# Altium schematic sheet FEZ-Feather-Micro.SchDoc(FEZ-Feather-Micro)
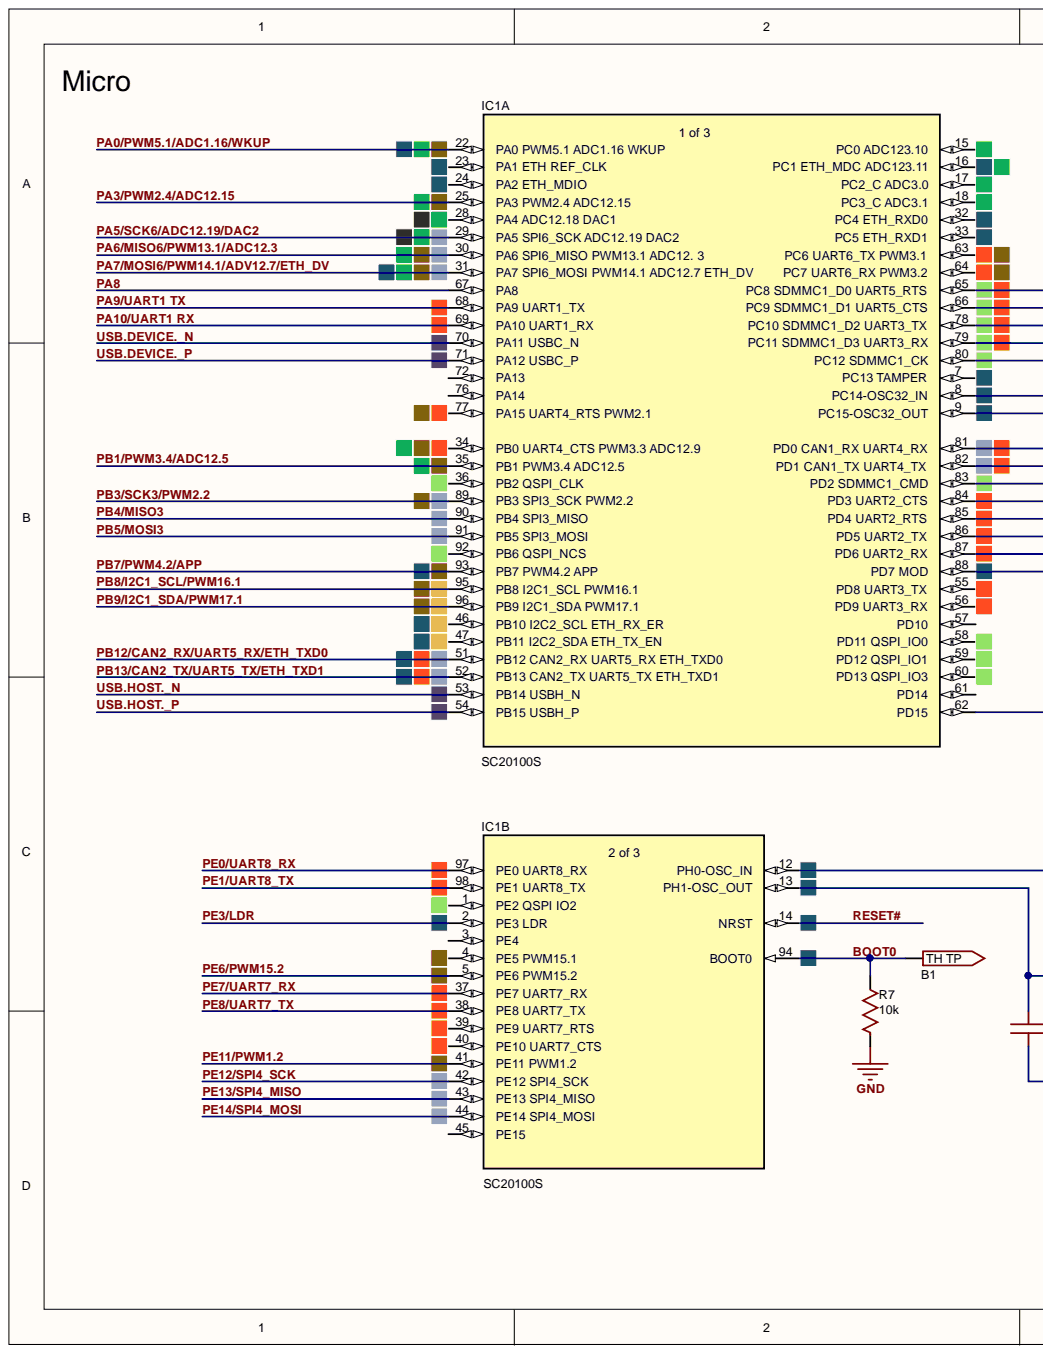
[diagram p0: FEZ-Feather-Micro.SchDoc, left]
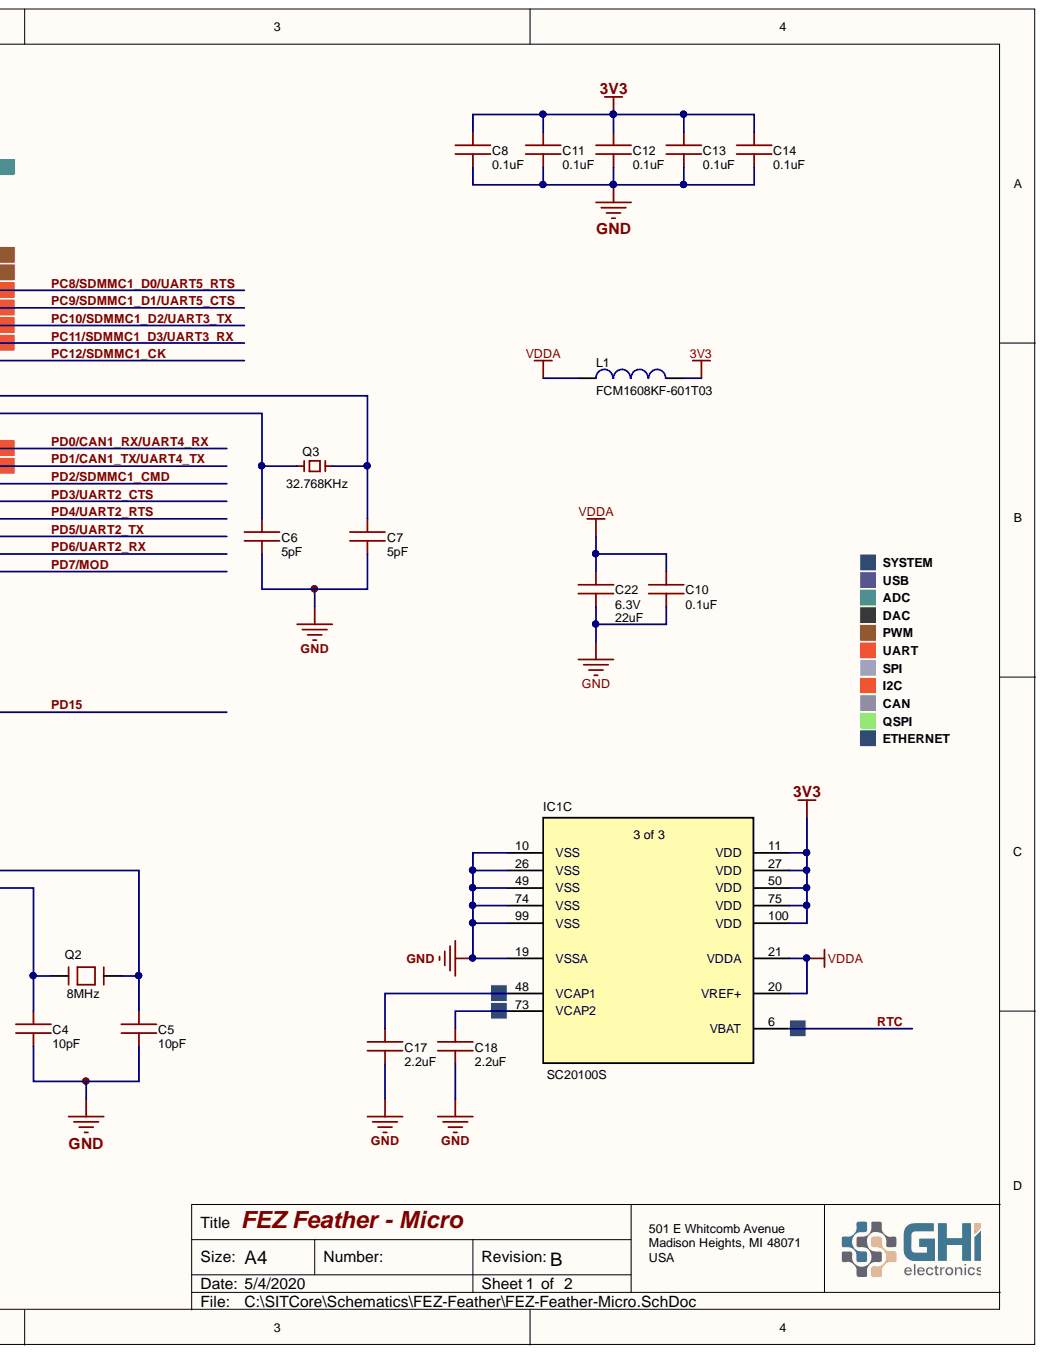
[diagram p1: FEZ-Feather-Micro.SchDoc, right]

## Parts
B1  TH Testpad 32mil (32mil ar)  [32mil TH]  (value not drawn)  (no BOM)
C4  value 10pF  [C0402]  (hidden comment: 0402 Cap 10pF)
C5  value 10pF  [C0402]  (hidden comment: 0402 Cap 10pF)
C6  value 5pF  [C0402]  (hidden comment: 0402 Cap 5pF)
C7  value 5pF  [C0402]  (hidden comment: 0402 Cap 5pF)
C8  value 0.1uF  [C0402]  (hidden comment: 0402 Cap 0.1uF)
C10  value 0.1uF  [C0402]  (hidden comment: 0402 Cap 0.1uF)
C11  value 0.1uF  [C0402]  (hidden comment: 0402 Cap 0.1uF)
C12  value 0.1uF  [C0402]  (hidden comment: 0402 Cap 0.1uF)
C13  value 0.1uF  [C0402]  (hidden comment: 0402 Cap 0.1uF)
C14  value 0.1uF  [C0402]  (hidden comment: 0402 Cap 0.1uF)
C17  value 2.2uF  [C0603 (1608 Metric)]  (hidden comment: 0603 Cap 2.2uF)
C18  value 2.2uF  [C0603 (1608 Metric)]  (hidden comment: 0603 Cap 2.2uF)
C22  value 22uF  Voltage: 6.3V  [C0805 L]  (hidden comment: 0805 Cap 22uF)
IC1  SC20100S  [LQFP100]  (parts A,B,C)
IC1D  SC20100S  [LQFP100]  (no BOM)
L1  value FCM1608KF-601T03  [L0603]  (hidden comment: BEAD)
Q2  value 8MHz  [CRYSTAL_3.2X2.5]  (hidden comment: CRYSTAL3.2X2.5)
Q3  value 32.768KHz  [Crystal 3.2mm x 1.5mm]  (hidden comment: 32.768KHz 7pF 20ppm)
R7  value 10k  [R0603 (1608 Metric)]  (hidden comment: 0603 10K)

## Nets
3V3: C8.2 C11.2 C12.2 C13.2 C14.2 IC1.11(VDD) IC1.27(VDD) IC1.50(VDD) IC1.75(VDD) IC1.100(VDD) L1.2
GND: C4.1 C5.1 C6.1 C7.1 C8.1 C10.1 C11.1 C12.1 C13.1 C14.1 C17.1 C18.1 C22.1 IC1.10(VSS) IC1.19(VSSA) IC1.26(VSS) IC1.49(VSS) IC1.74(VSS) IC1.99(VSS) R7.1
VDDA: C10.2 C22.2 IC1.20(VREF+) IC1.21(VDDA) L1.1
BOOT0: B1.1 IC1.94(BOOT0) R7.2
PA0/PWM5.1/ADC1.16/WKUP: IC1.22(PA0_PWM5.1_ADC1.16_WKUP)
PA3/PWM2.4/ADC12.15: IC1.25(PA3_PWM2.4_ADC12.15)
PA5/SCK6/ADC12.19/DAC2: IC1.29(PA5_SPI6_SCK_ADC12.19_DAC2)
PA6/MISO6/PWM13.1/ADC12.3: IC1.30
PA7/MOSI6/PWM14.1/ADV12.7/ETH_DV: IC1.31
PA8: IC1.67(PA8)
PA9/UART1 TX: IC1.68(PA9_UART1_TX)
PA10/UART1 RX: IC1.69(PA10_UART1_RX)
PB1/PWM3.4/ADC12.5: IC1.35(PB1_PWM3.4_ADC12.5)
PB3/SCK3/PWM2.2: IC1.89(PB3_SPI3_SCK_PWM2.2)
PB4/MISO3: IC1.90(PB4_SPI3_MISO)
PB5/MOSI3: IC1.91(PB5_SPI3_MOSI)
PB7/PWM4.2/APP: IC1.93(PB7_PWM4.2_APP)
PB8/I2C1_SCL/PWM16.1: IC1.95(PB8_I2C1_SCL_PWM16.1)
PB9/I2C1_SDA/PWM17.1: IC1.96(PB9_I2C1_SDA_PWM17.1)
PB12/CAN2_RX/UART5_RX/ETH_TXD0: IC1.51(PB12_CAN2_RX_UART5_RX_ETH_TXD0)
PB13/CAN2_TX/UART5_TX/ETH_TXD1: IC1.52(PB13_CAN2_TX_UART5_TX_ETH_TXD1)
PC8/SDMMC1_D0/UART5_RTS: IC1.65(PC8_SDMMC1_D0_UART5_RTS)
PC9/SDMMC1_D1/UART5_CTS: IC1.66(PC9_SDMMC1_D1_UART5_CTS)
PC10/SDMMC1_D2/UART3_TX: IC1.78(PC10_SDMMC1_D2_UART3_TX)
PC11/SDMMC1_D3/UART3_RX: IC1.79(PC11_SDMMC1_D3_UART3_RX)
PC12/SDMMC1_CK: IC1.80(PC12_SDMMC1_CK)
PD0/CAN1_RX/UART4_RX: IC1.81(PD0_CAN1_RX_UART4_RX)
PD1/CAN1_TX/UART4_TX: IC1.82(PD1_CAN1_TX_UART4_TX)
PD2/SDMMC1_CMD: IC1.83(PD2_SDMMC1_CMD)
PD3/UART2_CTS: IC1.84(PD3_UART2_CTS)
PD4/UART2_RTS: IC1.85(PD4_UART2_RTS)
PD5/UART2_TX: IC1.86(PD5_UART2_TX)
PD6/UART2_RX: IC1.87(PD6_UART2_RX)
PD7/MOD: IC1.88(PD7_MOD)
PD15: IC1.62(PD15)
PE0/UART8_RX: IC1.97(PE0_UART8_RX)
PE1/UART8_TX: IC1.98(PE1_UART8_TX)
PE3/LDR: IC1.2(PE3_LDR)
PE6/PWM15.2: IC1.5(PE6_PWM15.2)
PE7/UART7_RX: IC1.37(PE7_UART7_RX)
PE8/UART7_TX: IC1.38(PE8_UART7_TX)
PE11/PWM1.2: IC1.41(PE11_PWM1.2)
PE12/SPI4_SCK: IC1.42(PE12_SPI4_SCK)
PE13/SPI4_MISO: IC1.43(PE13_SPI4_MISO)
PE14/SPI4_MOSI: IC1.44(PE14_SPI4_MOSI)
RESET#: IC1.14(NRST)
RTC: IC1.6(VBAT)
USB.DEVICE._N: IC1.70(PA11_USBC_N)
USB.DEVICE._P: IC1.71(PA12_USBC_P)
USB.HOST._N: IC1.53(PB14_USBH_N)
USB.HOST._P: IC1.54(PB15_USBH_P)
NetC4_2: C4.2 IC1.13(PH1-OSC_OUT) Q2.1
NetC5_2: C5.2 IC1.12(PH0-OSC_IN) Q2.3
NetC6_2: C6.2 IC1.9(PC15-OSC32_OUT) Q3.1
NetC7_2: C7.2 IC1.8(PC14-OSC32_IN) Q3.2
NetC17_2: C17.2 IC1.48(VCAP1)
NetC18_2: C18.2 IC1.73(VCAP2)

Not connected: IC1 1(PE2_QSPI_IO2) 3(PE4) 4(PE5_PWM15.1) 7(PC13_TAMPER) 15(PC0_ADC123.10) 16(PC1_ETH_MDC_ADC123.11) 17(PC2_C_ADC3.0) 18(PC3_C_ADC3.1) 23(PA1_ETH_REF_CLK) 24(PA2_ETH_MDIO) 28(PA4_ADC12.18_DAC1) 32(PC4_ETH_RXD0) 33(PC5_ETH_RXD1) 34(PB0_UART4_CTS_PWM3.3_ADC12.9) 36(PB2_QSPI_CLK) 39(PE9_UART7_RTS) 40(PE10_UART7_CTS) 45(PE15) 46(PB10_I2C2_SCL_ETH_RX_ER) 47(PB11_I2C2_SDA_ETH_TX_EN) 55(PD8_UART3_TX) 56(PD9_UART3_RX) 57(PD10) 58(PD11_QSPI_IO0) 59(PD12_QSPI_IO1) 60(PD13_QSPI_IO3) 61(PD14) 63(PC6_UART6_TX_PWM3.1) 64(PC7_UART6_RX_PWM3.2) 72(PA13) 76(PA14) 77(PA15_UART4_RTS_PWM2.1) 92(PB6_QSPI_NCS)

## Library data of the parts
B1 = TH Testpad 32mil (32mil ar): TH Testpad 32mil (32mil annular ring); Library Name: GHI.SchLib
C4 C5 = 0402 Cap 10pF: 10pF ±0.5pF 50V C0G 0402 Multilayer Ceramic Capacitors MLCC - SMD/SMT RoHS; Mfr.Part #: CL05C100DB5NNNC; Manufacturer: Samsung Electro-Mechanics; Voltage: 50V; Tolerance: ±0.5pF; Package: 0402; LCSC Part #: C307440; Component Kind: Standard; Category: Ceramic; Capacitance: 10pF; Library Name: GHI-Passives.SchLib
C6 C7 = 0402 Cap 5pF: 5pF ±0.1pF 50V C0G 0402 Multilayer Ceramic Capacitors MLCC - SMD/SMT RoHS; Mfr.Part #: CL05C050BB5NNNC; Manufacturer: Samsung Electro-Mechanics; Voltage: 50V; Tolerance: ±0.1pF; Package: 0402; LCSC Part #: C318616; Component Kind: Standard; Category: Ceramic; Capacitance: 5pF; Library Name: GHI-Passives.SchLib
C8 C10-C14 = 0402 Cap 0.1uF: 0.1uF ±10% 16V X7R 0402 Multilayer Ceramic Capacitors MLCC - SMD/SMT RoHS; Mfr.Part #: CC0402KRX7R7BB104; Voltage: 16V; Tolerance: ±10%; Package: 0402; LCSC Part #: C60474; Component Kind: Standard; Category: Ceramic; Capacitance: 0.1uF; Library Name: GHI-Passives.SchLib
C17 C18 = 0603 Cap 2.2uF: 2.2uF ±10% 10V X5R 0603 Multilayer Ceramic Capacitors MLCC - SMD/SMT RoHS; Mfr.Part #: CC0603KRX5R6BB225; Manufacturer: YAGEO; Voltage: 10V; Tolerance: ±10%; Package: 0603; LCSC Part #: C106213; Component Kind: Standard; Category: Ceramic; Capacitance: 2.2uF; Library Name: GHI-Passives.SchLib
C22 = 0805 Cap 22uF: 22uF ±20% 6.3V X5R 0805 Multilayer Ceramic Capacitors MLCC - SMD/SMT RoHS; Mfr.Part #: CL21A226MQCLRNC; Tolerance: ±20%; Package: 0805; LCSC Part #: C307530; Component Kind: Standard; Category: Ceramic; Capacitance: 22uF; Library Name: GHI-Passives.SchLib
IC1 IC1D = SC20100S: No Description Available; Manufacturer_Part_Number: stm32h7x3vit; Vendor: STMicroelectronics; Value: Value; Type: DEV; RefDes: RefDes; Library Name: GHI-Integrated Circuits.SchLib; Copyright: Copyright (C) 2016 Accelerated Designs. All rights reserved
  IC1: Component Kind: Standard
L1 = (no symbol name): 600Ω @ 100MHz 350mA 1 500mΩ 0603 Ferrite Beads RoHS; Revision: TAITEC; Package: 0603; Mfr.Part #: FCM1608KF-601T03; LCSC Part #: C141723; Component Kind: Standard; Library Name: GHI.SchLib
Q2 = CRYSTAL3.2X2.5: 4 pad 3.2 mm x 2.5 mm Crystal; SymbolName_1: CRYSTAL.2PAD; GateName_1: G$1; LibraryName: GHI2; DeviceSetName: CRYSTAL3.2X2.5; Component Kind: Standard; Library Name: GHI.SchLib
Q3 = 32.768KHz 7pF 20ppm 2 Pad 3.2mm x 1.5mm: Crystal 2 Pad 3.2mm x 1.5mm 32.768KHz; Manufacturer Part Number: 6K30032768K0702070401; GHI Part Number: EPC-Q32KHZ; Shunt Capacitance: 1.3pF Typ; Mode: AT/Fundamental; Load Capacitance: 10 pF; Frequency Tolerance: +- 20ppm; Equivalent Series Resistance: 70k Ohm Max; Driver Level (Typical): 1uW Max; Aging @25 C 1st Year (Max): +- 3ppm/year; Storage Temperature: -55C to +125 C; Shock Resistance: N/A; Operating Temperature Range: -40 C to +85 C; Library Name: GHI.SchLib; Frequency Stability: N/A; Frequency: 32.768KHz; Component Kind: Standard
R7 = 0603 Res 10K: 10K ±5% 1/10W ±100ppm/℃ 0603 Chip Resistor - Surface Mount RoHS; Mfr.Part #: RC0603JR-0710KL; Manufacturer: YAGEO; Voltage Rating: *V; Resistance Tolerance: ±5%; Resistance: 10k; Power Rating: 1/10W; Package: 0603; LCSC Part #: C99198; Component Kind: Standard; Library Name: GHI-Passives.SchLib
